# Altium schematic sheet PowerPath.SchDoc
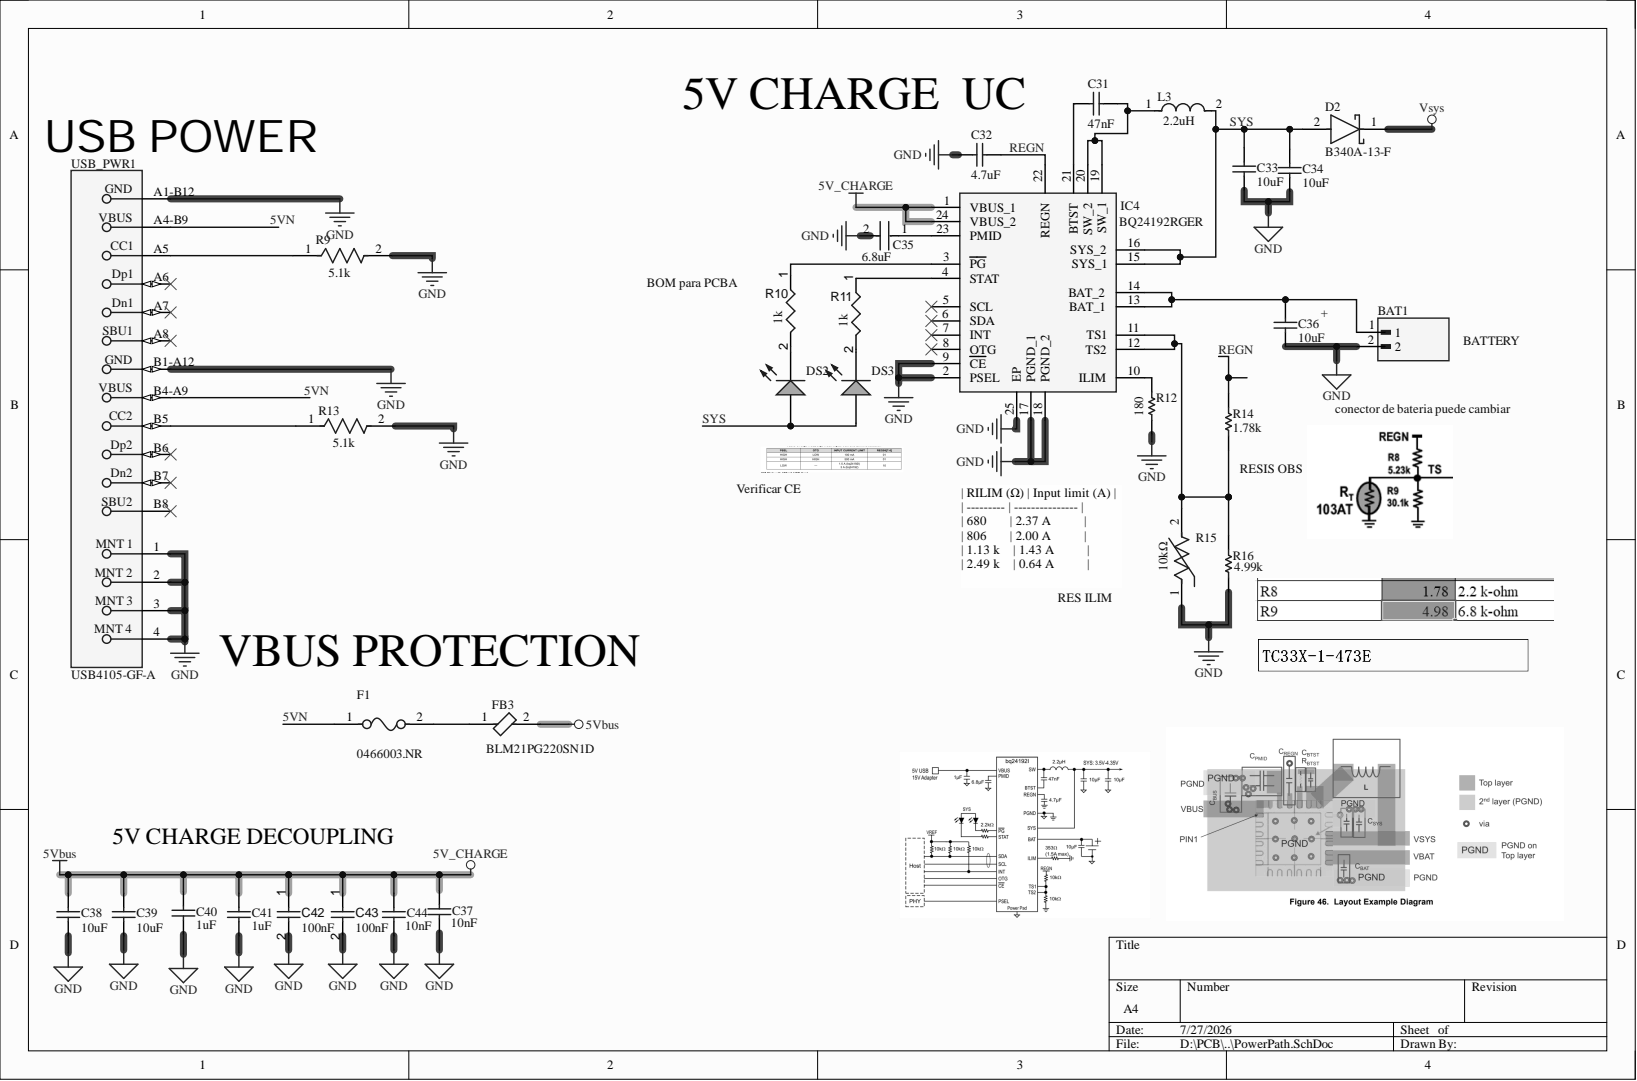
[diagram p0: PowerPath.SchDoc]

## Parts
BAT1  S2B-XH-A(LF)(SN)  [JST_S2B-XH-A(LF)(SN)]  (value not drawn)
C31  value 47nF  [CAPC1608X92X38ML15T08]  (hidden comment: VJ0603Y473KXAAC)
C32  value 4.7uF  [CAPC0603(1608)100_M]  (hidden comment: CAP 4.7uF 10V 0603(1608))
C33  value 10uF  [CAPC0603(1608)100_M]  (hidden comment: CAP 10uF 6.3V 0603(1608))
C34  value 10uF  [CAPC0603(1608)100_M]  (hidden comment: CAP 10uF 6.3V 0603(1608))
C35  Capacitance: 6.8uF  [FP-C1608-080-0_1-IPC_A]  (hidden comment: C1608X6S0G685K080AC)
C36  value 10uF  [CAPC0603(1608)100_M]  (hidden comment: CAP 10uF 6.3V 0603(1608))
C37  value 10nF  [CAPC0603X33X15ML03T05]  (hidden comment: 0201ZC103JAT2A)
C38  value 10uF  [CAPC0603(1608)100_M]  (hidden comment: CAP 10uF 6.3V 0603(1608))
C39  value 10uF  [CAPC0603(1608)100_M]  (hidden comment: CAP 10uF 6.3V 0603(1608))
C40  value 1uF  [CAPC1608X90X35ML15T15]  (hidden comment: 0603YD105KAT4A)
C41  value 1uF  [CAPC1608X90X35ML15T15]  (hidden comment: 0603YD105KAT4A)
C42  value 100nF  [CAPC1005X56X25ML10T15]  (hidden comment: Capacitor 0.1 uF +/- 5% 16 V 0402)
C43  value 100nF  [CAPC1005X56X25ML10T15]  (hidden comment: Capacitor 0.1 uF +/- 5% 16 V 0402)
C44  value 10nF  [CAPC0603X33X15ML03T05]  (hidden comment: 0201ZC103JAT2A)
D2  B340A-13-F  [FP-SMA-MFG]
DS2  LTST-C190KFKT  [LTST-C190KFKT_V]  (value not drawn)
DS3  LTST-C190KFKT  [LTST-C190KFKT_V]  (value not drawn)
F1  0466003.NR  [FP-0466-MFG]
FB3  BLM21PG220SN1D  [FP-BLM21-0_2-t0_85-e0_5-IPC_A]
IC4  BQ24192RGER  [QFN50P400X400X100-25N-D]
L3  Inductance: 2.2uH  [FP-MPX1D1250-MFG]  (hidden comment: MPX1D1250L2R2)
R9  Resistance: 5.1k  [FP-RMCF0603-IPC_A]  (hidden comment: RMCF0603JG5K10)
R10  value 1k  [RESC1608X55X25ML10T15]  (hidden comment: Resistor 10k +/-1% 0603 100 mW)
R11  value 1k  [RESC1608X55X25ML10T15]  (hidden comment: Resistor 10k +/-1% 0603 100 mW)
R12  value 180  [RESC1608X55X25ML10T15]  (hidden comment: RC0603FR-07180RL)
R13  Resistance: 5.1k  [FP-RMCF0603-IPC_A]  (hidden comment: RMCF0603JG5K10)
R14  value 1.78k  [RESC1005X40X25ML05T05]  (hidden comment: CRCW0402178KFKED)
R15  Resistance: 10kΩ  [FP-NTCLE100E3103JT2-MFG]  (hidden comment: NTC)
R16  value 4.99k  [RESC1005X40X25ML05T10]  (hidden comment: RC0402FR-07499KL)
USB_PWR1  USB4105-GF-A  [FP-USB4105-GF-A-MFG]

## Nets
5V_CHARGE: C37.2 C38.2 C39.2 C40.1 C41.1 C42.1 C43.1 C44.2 FB3.2 IC4.1(VBUS_1) IC4.24(VBUS_2)
GND: BAT1.2 C32.2 C33.1 C34.1 C35.2 C36.1 C37.1 C38.1 C39.1 C40.2 C41.2 C42.2 C43.2 C44.1 IC4.2(PSEL) IC4.9(CE) IC4.17(PGND_1) IC4.18(PGND_2) IC4.25(EP) R9.2 R12.2 R13.2 R15.1 R16.1 USB_PWR1.1 USB_PWR1.2 USB_PWR1.3 USB_PWR1.4 USB_PWR1.A1-B12 USB_PWR1.B1-A12
Vsys: D2.1
5VN: F1.1 USB_PWR1.A4-B9 USB_PWR1.B4-A9
REGN: C32.1 IC4.22(REGN) R14.2
SYS: C33.2 C34.2 D2.2 DS2.1 DS3.1 IC4.15(SYS_1) IC4.16(SYS_2) L3.2
NetBAT1_1: BAT1.1 C36.2 IC4.13(BAT_1) IC4.14(BAT_2)
NetC31_1: C31.1 IC4.21(BTST)
NetC31_2: C31.2 IC4.19(SW_1) IC4.20(SW_2) L3.1
NetC35_1: C35.1 IC4.23(PMID)
NetDS2_2: DS2.2 R10.2
NetDS3_2: DS3.2 R11.2
NetF1_2: F1.2 FB3.1
NetIC4_3: IC4.3(PG) R10.1
NetIC4_4: IC4.4(STAT) R11.1
NetIC4_10: IC4.10(ILIM) R12.1
NetIC4_11: IC4.11(TS1) IC4.12(TS2) R14.1 R15.2 R16.2
NetR9_1: R9.1 USB_PWR1.A5
NetR13_1: R13.1 USB_PWR1.B5

Not connected: IC4 5(SCL) 6(SDA) 7(INT) 8(OTG); USB_PWR1 A6 A7 A8 B6 B7 B8

## Library data of the parts
BAT1 = CMP-002-00076-2: Connector Header Through Hole, Right Angle 2 position 0.098 (2.50mm); MF: JST Sales; Case/Package: None; Check_prices: https://www.snapeda.com/parts/S2B-XH-A(LF)(SN)/JST/view-part/?ref=eda; Component Kind: Standard; Component Type: Standard; Design Item ID: S2B-XH-A(LF)(SN); MP: S2B-XH-A(LF)(SN); Pins: 2; SnapEDA_Link: https://www.snapeda.com/parts/S2B-XH-A(LF)(SN)/JST/view-part/?ref=snap; Source: S2B-XH-A(LF)(SN).SchLib; Library Name: Carlos Christopher Carbajal Jordán
C31 = CMP-2006-01132-1: Manufacturer: Vitramon; Component Kind: Standard; Library Name: Altium Content Vault; Part Number: VJ0603Y473KXAAC
C32 = CMP-1035-02785-1: CAP 4.7uF 10V ±10% 0603 (1608 Metric) Thickness 1mm SMD; Case-EIA: 0603; Case-Metric: 1608; Tolerance: Â±10%; Component Kind: Standard; Library Name: Altium Content Vault; Max Thickness: 1 mm; Rated Voltage: 10 V; Technology: SMT; Value: 4.7uF
C33 C34 C36 C38 C39 = CMP-1035-02796-1: CAP 10uF 6.3V ±10% 0603 (1608 Metric) Thickness 1mm SMD; Case-EIA: 0603; Case-Metric: 1608; Tolerance: Â±10%; Component Kind: Standard; Library Name: Altium Content Vault; Max Thickness: 1 mm; Rated Voltage: 6.3 V; Technology: SMT
C35 = CMP-08246-004451-1: Multilayer Ceramic Capacitors 6.8μF ±10% 4V X6S SMD 0603; Case Code (Imperial): 0603; Case Code (Metric): 1608; Case/Package: 0603; Component Kind: Standard; Dielectric Material: Ceramic; Lead Free: Lead Free; Length: 0.063inch; Library Name: Altium Content Vault; Max Operating Temperature: 105°C; Min Operating Temperature: -55°C; Mount: Surface Mount; Package Quantity: 4000; Packaging: Tape and Reel; RoHS Compliant: Yes; Series: C; Thickness: 0.035inch; Tolerance: 10%; Voltage Rating: 4V; Voltage Rating (DC): 4V; Width: 0.031inch
C37 C44 = CMP-2010-00529-1: Manufacturer: AVX Interconnect / Elco; Component Kind: Standard; Library Name: Altium Content Vault; Part Number: 0201ZC103JAT2A
C40 C41 = CMP-2006-04533-1: Manufacturer: AVX Interconnect / Elco; Component Kind: Standard; Library Name: Altium Content Vault; Part Number: 0603YD105KAT4A
C42 C43 = CMP-001-00001-6: Chip Capacitor, 0.1 uF, +/- 5%, 16 V, 0402 (1005 Metric); Capacitor Type: Ceramic; Voltage Rating: 16V; Case/Package: 0402; Component Kind: Standard; Max Operating Temperature: 125°C; Min Operating Temperature: -55°C; Mounting Technology: SM; Pins: 2; RoHS Compliant: True; Tolerance: 5%; Library Name: Carlos Christopher Carbajal Jordán
D2 = CMP-1667-00003-3: DIODE SCHOTTKY 40V 3A SMA; Capacitance: 250pF; Element Configuration: Single; Forward Voltage: 500mV; Max Forward Surge Current (Ifsm): 80A; Max Reverse Current: 500uA; Polarity: Standard; Average Rectified Current: 3A; Case/Package: SMA; Component Kind: Standard; Contact Plating: Tin; Current: 3A; Current Rating: 3A; Forward Current: 3A; Height: 2.3mm; Lead Free: Lead Free; Length: 4.6mm; Library Name: Altium Content Vault; Max Operating Temperature: 125°C; Max Repetitive Reverse Voltage (Vrrm): 40V; Max Reverse Voltage (DC): 40V; Min Operating Temperature: -55°C; Mount: Surface Mount; Number of Pins: 2; Output Current: 3A; Package Quantity: 5000; Packaging: Tape and Reel; Peak Non-Repetitive Surge Current: 80A; Radiation Hardening: No; REACH SVHC: No SVHC; RoHS Compliant: Yes; Series: -; Termination: SMD/SMT; Voltage: 40V; Voltage Rating (DC): 40V; Weight: 0.002258oz; Width: 2.92mm
DS2 DS3 = CMP-007-00023-2: LED Uni-Color Orange, 75 mW, 30 mA, -35 to 85 degC, 2-Pin SMD, RoHS, Tape and Reel; Manufacturer: Lite-On; Forward Current: 20mA; Forward Voltage: 2V; Lens Style: Diffused; Luminous Intensity: 90mcd; Power: 75mW; Viewing Angle: 130degrees; Case/Package: 0603; Colour: Orange; Component Kind: Standard; Datasheet URL: http://optoelectronics.liteon.com/upload/download/DS-22-99-0186/S_110_LTST-C190KFKT.pdf; Datasheet Version: Rev. I, 09/2011; Illumination Colour: Orange; Manufacturer URL: http://www.us.liteon.com/; Max Operating Temperature: 85°C; Min Operating Temperature: -55°C; Mounting Technology: SM; Nominal Vf: 2V; Package Description: 2-Pin Surface Mount Device, Body 1.6 x 0.8 mm; Package Quantity: 3000; Package Reference: C190KFKT; Packaging: TapeandReel; Pins: 2; RoHS Compliant: True; Library Name: Carlos Christopher Carbajal Jordán
F1 = CMP-08605-000054-1: FUSE BOARD MNT 3A 32VAC/VDC 1206; Manufacturer: Littelfuse; Fuse Blow Speed: Fast; Fuse I2T: 0.576A2Sec; Fuse Interrupt Ac Current: 50A; Fuse Voltage Drop: 75.99mV; Max Fuse Ac Voltage: 32V; Resistance: 0.019Ω; Value: 0.019Ω; Automotive: No; Category: Fuse; Component Kind: Standard; ComponentLink2Description: Datasheet; ComponentLink2URL: https://www.littelfuse.com/~/media/electronics/datasheets/fuses/littelfuse_fuse_466_datasheet.pdf.pdf; Device Class L1: Circuit Protection; Device Class L2: Fuses; Device Class L3: unset; Digikey Description: FUSE BOARD MNT 3A 32VAC/VDC 1206; Fuse Current: 3A; Fuse Interrupt Dc Current: 50A; Height: 0.66mm; Lead Free: Yes; Library Name: Altium Content Vault; Manufacturer Part Number: 0466003.NR; Max Fuse Dc Voltage: 32V; Mouser Description: Surface Mount Fuses 32V 3A VFA Slimline; Octopart: https://octopart.com/0466003.nr-littelfuse-565175; Package: 0466; RoHS: TRUE; Temperature: -55°C to +90°C; Temperature Range High: +90°C; Temperature Range Low: -55°C; Voltage Rating: 32V
FB3 = CMP-0686-00622-4: Chip Ferrite Bead, 0805, 22Ω @ 100MHz, 0.009Ω, 25%, 6A; Manufacturer Series: BLM21P; DC Resistance (DCR): 9mR; Impedance: 22R; Material: Steel; Number of Circuits: 1; Resistance: 10mR; Shielding: Unshielded; Case Code (Imperial): 0805; Case Code (Metric): 2012; Case/Package: 0805; Component Kind: Standard; Core Material: Ferrite; Current Rating: 6A; DC Current: 6A; DC Resistance-Max: 9mR; Depth: 1.25mm; Height: 0.85mm; Lead Free: Lead Free; Length: 2mm; Library Name: Altium Content Vault; Max Current Rating: 6A; Max DC Current: 6A; Max Operating Temperature: 125°C; Min Operating Temperature: -55°C; Number of Functions: 1; Number of Lines: 1; Number of Pins: 2; Package Quantity: 1; Packaging: Tape and Reel; Radiation Hardening: No; REACH SVHC: No SVHC; RoHS Compliant: Yes; Series: BLM21P; Terminal Finish: Tin; Termination: SMD/SMT; Test Frequency: 100MHz; Tolerance: 25%; Weight: 0.000194oz; Width: 1.25mm
IC4 = BQ24192RGER: Integrated Circuit; Manufacturer_Name: Texas Instruments; Arrow Part Number: BQ24192RGER; Arrow Price/Stock: https://www.arrow.com/en/products/bq24192rger/texas-instruments; Component Kind: Standard; Datasheet Link: http://www.ti.com/lit/gpn/bq24192; Height: 1mm; Library Name: BQ24192RGER.SchLib; Manufacturer_Part_Number: BQ24192RGER; Mouser Part Number: 595-BQ24192RGER; Mouser Price/Stock: https://www.mouser.co.uk/ProductDetail/Texas-Instruments/BQ24192RGER?qs=2UFnnMkojnXNBLAi7bBu%2FA%3D%3D
L3 = CMP-03027-000008-1: FIXED IND 2.2UH 21A 4.8 MOHM SMD; Core Material: Metal; DC Resistance (DCR): 4.8mR; Saturation Current: 28.5A; Self Resonant Frequency: 21MHz; Shielding: Shielded; Test Frequency: 100kHz; Tolerance: 20%; Case/Package: Nonstandard; Component Kind: Standard; Library Name: Altium Content Vault; Max Operating Temperature: 155°C; Min Operating Temperature: -55°C; Mount: Surface Mount; RoHS Compliant: Yes; Series: METCOM MPX
R9 R13 = CMP-26527-001717-1: 5.1kΩ ±5% 0.1W 0603 Thick Film Chip Resistor AEC-Q200 compliant; Max Power Dissipation: 100mW; Temperature Coefficient: 200ppm/°C; Case Code (Imperial): 0603; Case Code (Metric): 1608; Case/Package: 0603; Component Kind: Standard; Contact Plating: Tin; Features: Automotive AEC-Q200; Height: 0.022inch; Length: 0.061inch; Library Name: Altium Content Vault; Max Operating Temperature: 155°C; Min Operating Temperature: -55°C; Mount: Surface Mount; Number of Terminations: 2; Package Quantity: 1; Packaging: Tape and Reel; Radiation Hardening: No; Resistor Type: Thick Film; RoHS Compliant: Yes; Series: RMCF; Tolerance: 5%; Width: 0.031inch
R10 R11 = CMP-009-00017-5: Chip Resistor, 10 KOhm, +/- 1%, 01 W, -55 to 155 degC, 0603 (1608 Metric); Power: 100mW; Voltage Rating: 50V; Case/Package: 0603; Component Kind: Standard; Max Operating Temperature: 155°C; Min Operating Temperature: -55°C; Mounting Technology: SM; Pins: 2; RoHS Compliant: True; Tolerance: 1%; Library Name: Carlos Christopher Carbajal Jordán
R12 = CMP-2000-06929-1: Chip Resistor, 180 Ohm, +/- 1%, 0.1 W, -55 to 155 degC, 0603 (1608 Metric), RoHS, Tape and Reel; Manufacturer: Yageo; Power Rating: 100mW; Resistance: 180Ohms; Temperature Coefficient: 100PPM/C; Voltage Rating: 50V; Case Code - in: 0603; Case Code - mm: 1608; Component Kind: Standard; Datasheet URL: http://www.yageo.com/documents/recent/PYu-RC_Group_51_RoHS_L_7.pdf; Datasheet Version: Rev. 7, 05/2017; Library Name: Altium Content Vault; Manufacturer URL: http://www.yageo.com/; Mounting Technology: SurfaceMount; Operating Temperature Range: -55Cto+155C; Package Description: 2-Pin Surface Mount Device, Body 1.6 x 0.8 mm; Package Reference: 0603; Packaging: Reel; Product: ThickFilmResistorsGeneralPurpose; Series: RC; Termination Style: SMD/SMT; Tolerance: 1%
R14 = CMP-1011-00762-2: Manufacturer: Vishay Dale; Component Kind: Standard; Library Name: Altium Content Vault; Part Number: CRCW0402178KFKED
R15 = CMP-00030-44472533-1: NTCLE100E3103JT2 Thermistor NTC 10K Ohm 5% 2-Pin Radial 3977K -4.5 to -4 T/R; Accuracy: 0.75%; Lead Diameter: 600µm; Max Power Dissipation: 500mW; Case/Package: Radial; Component Kind: Standard; Contact Plating: Tin; Depth: 3mm; Lead Pitch: 2.54mm; Length: 3.3mm; Library Name: Altium Content Vault; Max Operating Temperature: 125°C; Min Operating Temperature: -40°C; Mount: Through Hole; NexarPartId: 44472533; Number of Pins: 2; Packaging: Cut Tape (CT); Power Rating: 500mW; Radiation Hardening: No; REACH SVHC: Unknown; RoHS: Compliant; Schedule B: 8533400080, 8533400080; Termination: Radial; Tolerance: 5%
R16 = CMP-2002-07707-1: Manufacturer: Yageo / Phycomp; Component Kind: Standard; Library Name: Altium Content Vault; Part Number: RC0402FR-07499KL
USB_PWR1 = CMP-002-00071-1: USB Connector, 24 Contact(s), Female, Right Angle, Solder Terminal, Locking, Receptacle; Voltage Rating: 20V; Component Kind: Standard; Gender: Receptacle; Max Operating Temperature: 85°C; Min Operating Temperature: -40°C; Pins: 24; Library Name: Carlos Christopher Carbajal Jordán
